# Altium schematic sheet Z6 - RF Array Board.SchDoc
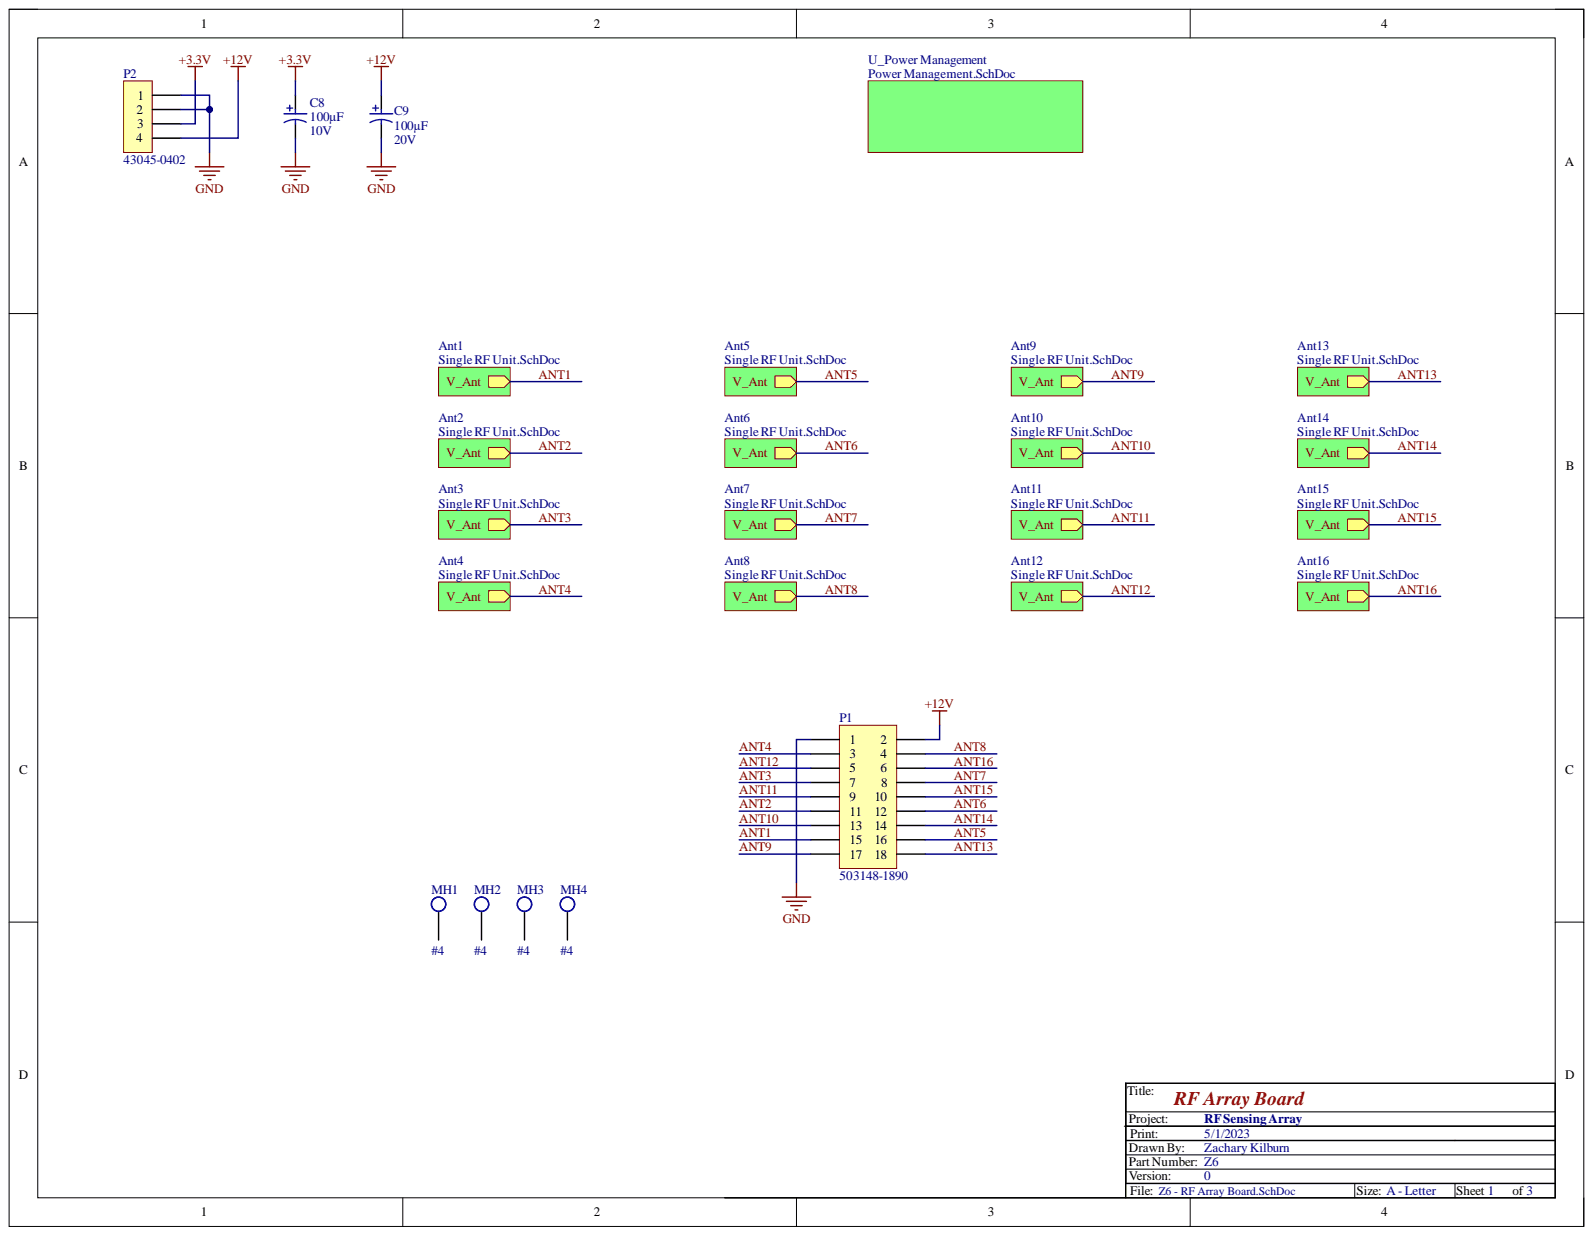
[diagram p0: Z6 - RF Array Board.SchDoc]

## Parts
C8  value 100µF  Voltage: 10V  [PCC-KEMT-T495-D-RN]  (hidden comment: T495D107K010ATE100)
C9  value 100µF  Voltage: 20V  [PCC-KEMT-T495-X-RN]  (hidden comment: T495X107M020ATE100)
MH1  #4  [PCC-XXX-MH-#4-ROUND-PLATED-H128P230M290]  (no BOM)
MH2  #4  [PCC-XXX-MH-#4-ROUND-PLATED-H128P230M290]  (no BOM)
MH3  #4  [PCC-XXX-MH-#4-ROUND-PLATED-H128P230M290]  (no BOM)
MH4  #4  [PCC-XXX-MH-#4-ROUND-PLATED-H128P230M290]  (no BOM)
P1  503148-1890  [PCC-MOLX-503148-1890-R]
P2  43045-0402  [PCC-MOLX-43045-0402-R]

## Nets
+3.3V: C8.1 P2.3
+12V: C9.1 P1.2 P2.4
GND: C8.2 C9.2 P1.1 P2.1 P2.2
ANT1: P1.15
ANT2: P1.11
ANT3: P1.7
ANT4: P1.3
ANT5: P1.16
ANT6: P1.12
ANT7: P1.8
ANT8: P1.4
ANT9: P1.17
ANT10: P1.13
ANT11: P1.9
ANT12: P1.5
ANT13: P1.18
ANT14: P1.14
ANT15: P1.10
ANT16: P1.6
NetMH1_1: MH1.1
NetMH2_1: MH2.1
NetMH3_1: MH3.1
NetMH4_1: MH4.1

## Library data of the parts
C8 = CMP-000480-0: Capacitor, Tantalum, 100µF, 10V, SMD, Case Code D; Manufacturer: Kemet; PackingType: Cut Tape; Component Kind: Standard; ComponentLink1Description: Manufacturer URL; ComponentLink1URL: http://www.kemet.com/; ComponentLink2Description: Product URL; ComponentLink2URL: http://www.kemet.com/Tantalum-MnO2; ComponentLink3Description: Datasheet; ComponentLink3URL: https://api.kemet.com/component-edge/download/datasheet/T495D107K010ATE100.pdf; ComponentLink4Description: Package Specification; ComponentLink4URL: https://api.kemet.com/component-edge/download/datasheet/T495D107K010ATE100.pdf; HelpURL: https://api.kemet.com/component-edge/download/datasheet/T495D107K010ATE100.pdf; Library Name: Integrated_Library - 02.08.19 - 15.59.SchLib; MountingTechnology: Surface Mount; OperatingTemperature: -55C to +125C; PackageName: D; PartNumber: T495D107K010ATE100; RoHS: Yes
C9 = CMP-000779-0: Capacitor, Tantalum, 100µF, 20V, SMD, Case Code X; Manufacturer: Kemet; PackingType: Cut Tape; Component Kind: Standard; ComponentLink1Description: Manufacturer URL; ComponentLink1URL: http://www.kemet.com/; ComponentLink2Description: Product URL; ComponentLink2URL: http://www.kemet.com/Tantalum-MnO2; ComponentLink3Description: Datasheet; ComponentLink3URL: https://api.kemet.com/component-edge/download/datasheet/T495X107M020ATE100.pdf; ComponentLink4Description: Package Specification; ComponentLink4URL: https://api.kemet.com/component-edge/download/datasheet/T495X107M020ATE100.pdf; HelpURL: https://api.kemet.com/component-edge/download/datasheet/T495X107M020ATE100.pdf; MountingTechnology: Surface Mount; OperatingTemperature: -55C to +125C; PackageName: X; PartNumber: T495X107M020ATE100; RoHS: Yes; Library Name: IEC Primary Vault
MH1-MH4 = CMP-000163-4: #4 Mounting Hole, Round, Plated, No Vias, 1/4" Standoff Compatible Courtyard; Units: Imperial; Size: #4; Library Name: IEC Primary Vault
P1 = CMP-000073-0: Molex CLIK-Mate Series Connector, Dual-Row, 18-Position, Right Angle, 1.5mm Pitch; Current: 2A; MatingStyle: Plug; PackingType: Reel; Positions: 18; Rows: 2; Voltage: 100V; Component Kind: Standard; ComponentLink1Description: Manufacturer URL; ComponentLink1URL: http://www.molex.com; ComponentLink2Description: Product URL; ComponentLink2URL: http://www.molex.com/molex/products/datasheet.jsp?part=active/5031481890_PCB_RECEPTACLES.xml; ComponentLink3Description: Datasheet; ComponentLink3URL: http://www.molex.com/pdm_docs/ps/PS-503148-001-001.pdf; ComponentLink4Description: Package Specification; ComponentLink4URL: http://www.molex.com/pdm_docs/sd/5031481890_sd.pdf; HelpURL: http://www.molex.com/pdm_docs/sd/5031481890_sd.pdf; Manufacturer: Molex; MountingTechnology: Surface Mount; OperatingTemperature: -40C to +105C; Orientation: Right Angle; PackageName: CLIK-Mate; PartNumber: 503148-1890; Pitch: 1.5mm; RoHS: Yes; StorageTemperature: +5C to +35C; Library Name: IEC Primary Vault
P2 = CMP-000203-0: Molex Micro-Fit 3.0 Connector, Dual-Row, 4-Position, Right-Angle, 3mm Pitch; MatingStyle: Plug; Positions: 4; Rows: 2; Voltage: 250V; Component Kind: Standard; ComponentLink1Description: Manufacturer URL; ComponentLink1URL: http://www.molex.com; ComponentLink2Description: Product URL; ComponentLink2URL: http://www.molex.com/molex/products/datasheet.jsp?part=active/0430450402_PCB_HEADERS.xml; ComponentLink3Description: Datasheet; ComponentLink3URL: http://www.molex.com/pdm_docs/ps/PS-43045.pdf; ComponentLink4Description: Package Specification; ComponentLink4URL: http://www.molex.com/pdm_docs/sd/430450802_sd.pdf; HelpURL: http://www.molex.com/pdm_docs/ps/PS-43045.pdf; Manufacturer: Molex; MountingTechnology: Through Hole; OperatingTemperature: -40C to +105C; Orientation: Right Angle; PackageName: Micro-Fit 3.0; PartNumber: 43045-0402; Pitch: 3mm; RoHS: Yes; StorageTemperature: -40C to +105C; Library Name: IEC Primary Vault
